# Altium schematic sheet Vout.SchDoc
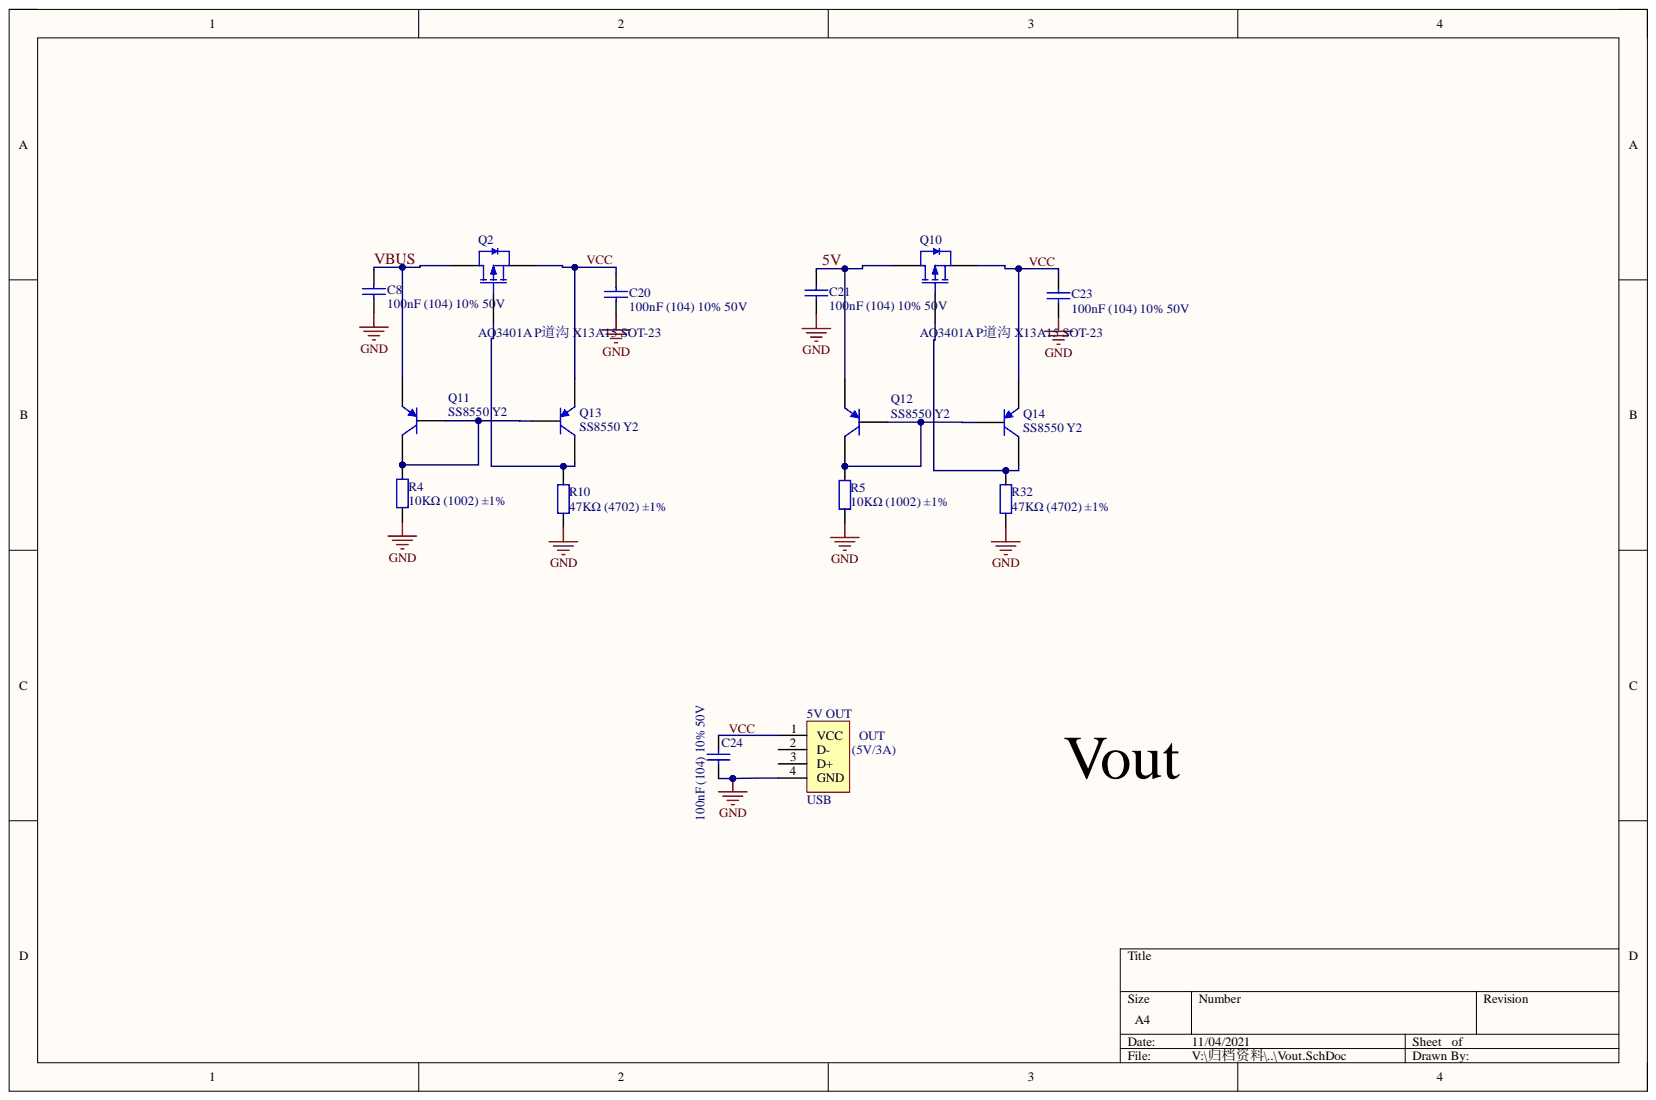
[diagram p0: Vout.SchDoc]

## Parts
5V_OUT  USB  [USB-A-母座贴片]
C8  100nF (104) 10% 50V  [电容-0603]
C20  100nF (104) 10% 50V  [电容-0603]
C21  100nF (104) 10% 50V  [电容-0603]
C23  100nF (104) 10% 50V  [电容-0603]
C24  100nF (104) 10% 50V  [电容-0603]
Q2  AO3401A P道沟 X13A15 SOT-23  [SOT23-3]
Q10  AO3401A P道沟 X13A15 SOT-23  [SOT23-3]
Q11  SS8550 Y2  [SOT23-3]
Q12  SS8550 Y2  [SOT23-3]
Q13  SS8550 Y2  [SOT23-3]
Q14  SS8550 Y2  [SOT23-3]
R4  10KΩ (1002) ±1%  [电阻_0603]
R5  10KΩ (1002) ±1%  [电阻_0603]
R10  47KΩ (4702) ±1%  [电阻_0603]
R32  47KΩ (4702) ±1%  [电阻_0603]

## Nets
GND: 5V_OUT.4(GND) C8.1 C20.1 C21.1 C23.1 C24.2 R4.1 R5.1 R10.1 R32.1
5V: C21.2 Q10.3 Q12.2
VBUS: C8.2 Q2.3 Q11.2
VCC: 5V_OUT.1(VCC) C20.2 C23.2 C24.1 Q2.2 Q10.2 Q13.2 Q14.2
NetQ2_1: Q2.1 Q13.3 R10.2
NetQ10_1: Q10.1 Q14.3 R32.2
NetQ11_1: Q11.1 Q11.3 Q13.1 R4.2
NetQ12_1: Q12.1 Q12.3 Q14.1 R5.2

Not connected: 5V_OUT 2(D-) 3(D+)

## Library data of the parts
5V_OUT = USB-A: BOM名称: USB-A型公接口; 版权归属: 源创客; Component Kind: Standard; Description: USB; 学习资源: www.ooocke.com; Library Name: SunFounder_Library.IntLib
C8 C20 C21 C23 C24 = 0603 100nF (104) 10% 50V: 贴片电容; Component Kind: Standard; ComponentLink1Description: 供应商链接; ComponentLink1URL: http://www.szlcsc.com/product/details_15331.html; Library Name: Miscellaneous Devices LC.IntLib; Package: 0603; Supplier: LC; SuppliersPartNumber: C14663
Q2 Q10 = AO3401A P道沟 X13A15 SOT-23: MOS(场效应管); Component Kind: Standard; ComponentLink1Description: 供应商链接; ComponentLink1URL: http://www.szlcsc.com/product/details_15801.html; Library Name: Miscellaneous Devices LC.IntLib; Package: SOT-23; Supplier: LC; SuppliersPartNumber: C15127
Q11-Q14 = SOT-23 SS8550 Y2: 三极管; Component Kind: Standard; ComponentLink1Description: 供应商链接; ComponentLink1URL: http://www.szlcsc.com/product/details_9037.html; Library Name: Miscellaneous Devices LC.IntLib; Package: SOT-23; Supplier: LC; SuppliersPartNumber: C8542
R4 R5 = 0603 10KΩ (1002) 1%: 贴片电阻; Component Kind: Standard; ComponentLink1Description: 供应商链接; ComponentLink1URL: http://www.szlcsc.com/product/details_26547.html; Library Name: Miscellaneous Devices LC.IntLib; Package: 0603; Supplier: LC; SuppliersPartNumber: C25804
R10 R32 = 0603 47KΩ (4702) 1%: 贴片电阻; Component Kind: Standard; ComponentLink1Description: 供应商链接; ComponentLink1URL: http://www.szlcsc.com/product/details_26562.html; Library Name: Miscellaneous Devices LC.IntLib; Package: 0603; Supplier: LC; SuppliersPartNumber: C25819
